# Altium schematic sheet WirelessEarBuds_PhotoHall.SchDoc
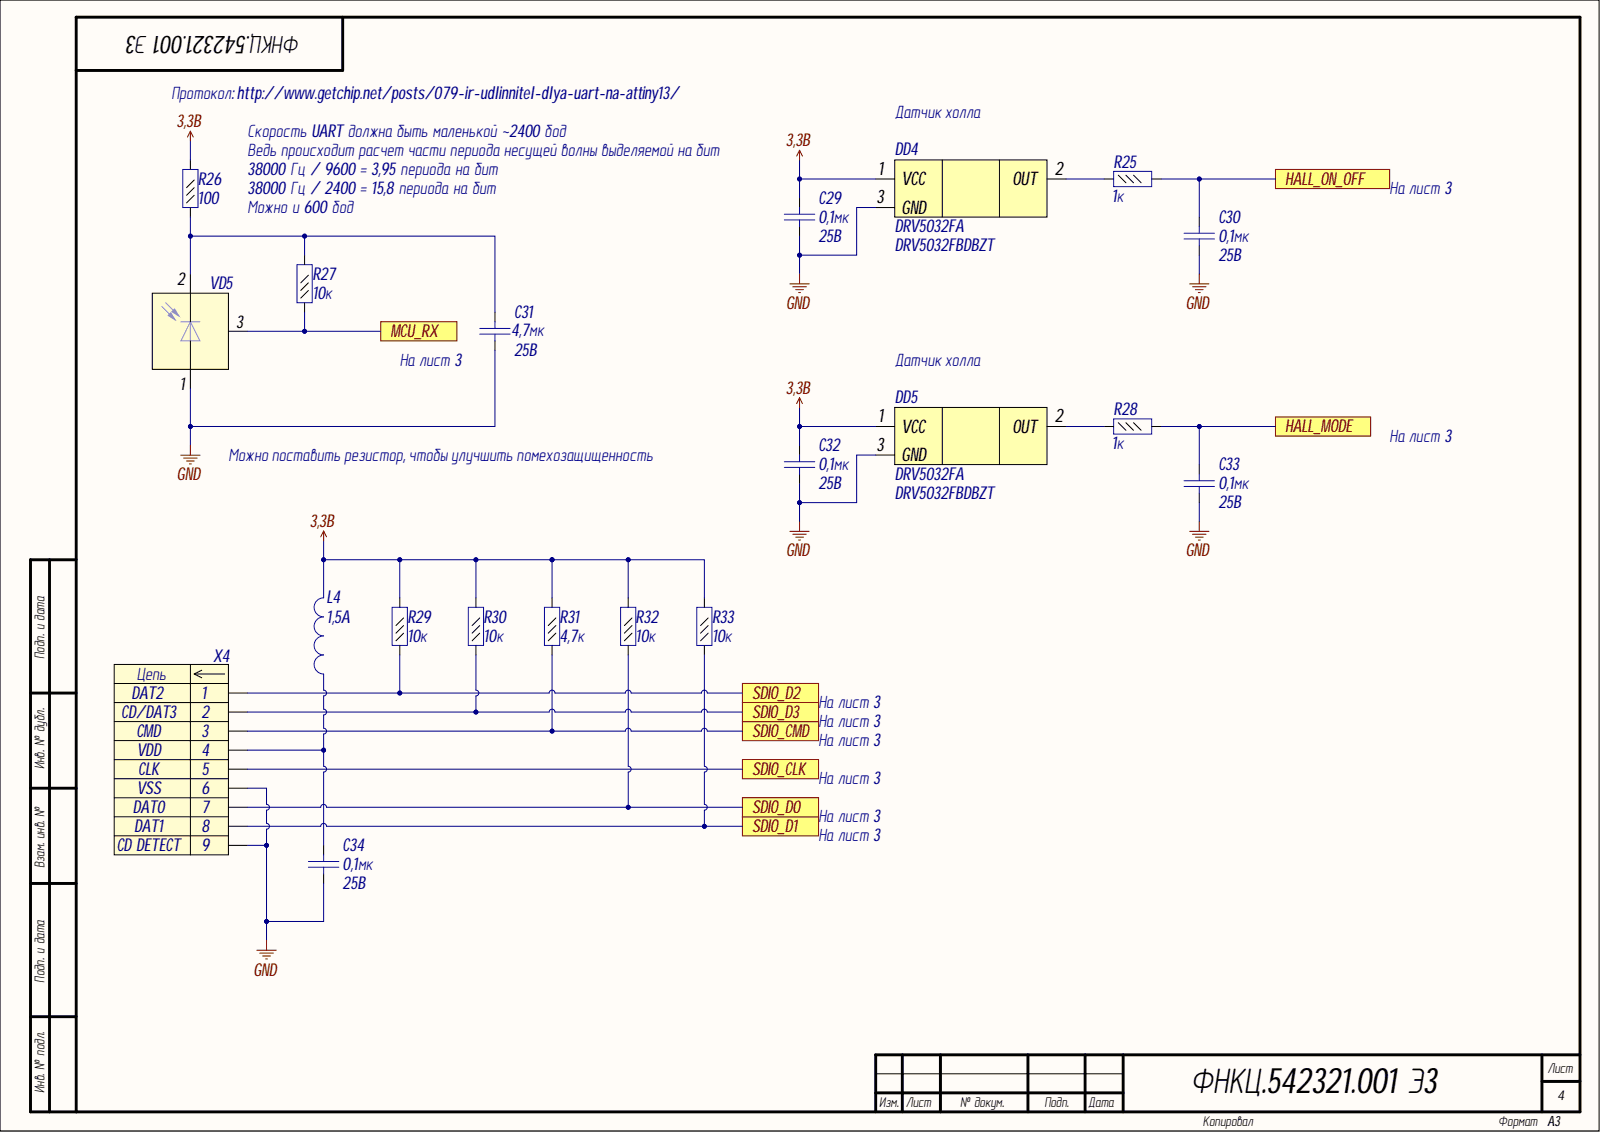
[diagram p0: WirelessEarBuds_PhotoHall.SchDoc]

## Parts
C29  value 0,1мк  Voltage: 25B  [C0402]  (hidden comment: Capacitor)
C30  value 0,1мк  Voltage: 25B  [C0402]  (hidden comment: Capacitor)
C31  value 4,7мк  Voltage: 25B  [C0805]  (hidden comment: Capacitor)
C32  value 0,1мк  Voltage: 25B  [C0402]  (hidden comment: Capacitor)
C33  value 0,1мк  Voltage: 25B  [C0402]  (hidden comment: Capacitor)
C34  value 0,1мк  Voltage: 25B  [C0402]  (hidden comment: Capacitor)
DD4  DRV5032  [SOT23-3]
DD5  DRV5032  [SOT23-3]
L4  Rated Current: 1,5A  [L0603]  (hidden comment: Inductor)
R25  value 1к  [R0402]  (hidden comment: Resistor_0,062Вт)
R26  value 100  [R0402]  (hidden comment: Resistor_0,062Вт)
R27  value 10к  [R0402]  (hidden comment: Resistor_0,062Вт)
R28  value 1к  [R0402]  (hidden comment: Resistor_0,062Вт)
R29  value 10к  [R0402]  (hidden comment: Resistor_0,062Вт)
R30  value 10к  [R0402]  (hidden comment: Resistor_0,062Вт)
R31  value 4,7к  [R0402]  (hidden comment: Resistor_0,062Вт)
R32  value 10к  [R0402]  (hidden comment: Resistor_0,062Вт)
R33  value 10к  [R0402]  (hidden comment: Resistor_0,062Вт)
VD5  IR_LED  [TVCAST]  (value not drawn)
X4  Contact_1: DAT2  Contact_2: CD/DAT3  Contact_3: CMD  Contact_4: VDD  Contact_5: CLK  Contact_6: VSS  Contact_7: DAT0  Contact_8: DAT1  [MICROSD]  (hidden comment: MICROSD-SDIO)

## Ports
HALL_MODE, HALL_ON_OFF, MCU_RX, SDIO_CLK, SDIO_CMD, SDIO_D0, SDIO_D1, SDIO_D2, SDIO_D3

## Nets
3,3B: C29.1 C32.1 DD4.1 DD5.1 L4.2 R26.2 R29.2 R30.2 R31.2 R32.2 R33.2
GND: C29.2 C30.2 C31.1 C32.2 C33.2 C34.2 DD4.3 DD5.3 VD5.1 X4.6 X4.9
HALL_MODE: C33.1 R28.2 ; port HALL_MODE
HALL_ON_OFF: C30.1 R25.2 ; port HALL_ON_OFF
MCU_RX: R27.1 VD5.3 ; port MCU_RX
SDIO_CLK: X4.5 ; port SDIO_CLK
SDIO_CMD: R31.1 X4.3 ; port SDIO_CMD
SDIO_D0: R32.1 X4.7 ; port SDIO_D0
SDIO_D1: R33.1 X4.8 ; port SDIO_D1
SDIO_D2: R29.1 X4.1 ; port SDIO_D2
SDIO_D3: R30.1 X4.2 ; port SDIO_D3
NetC31_2: C31.2 R26.1 R27.2 VD5.2
NetC34_1: C34.1 L4.1 X4.4
NetDD4_2: DD4.2 R25.1
NetDD5_2: DD5.2 R28.1

## Library data of the parts
C29 C30 C32-C34 = cap52: Конденсатор; Manufacture: Murata; Part Name: Ceramic; Part Number: GRM155F51E104Z**; Database Table Name: Capacitors$; TKE: Y5V; Component Kind: Standard; id: cap52; Library Name: MOP44.DbLib
C31 = cap154: Конденсатор; Manufacture: Murata; Part Name: Ceramic; Part Number: GRM21BR61E475KA12L; Database Table Name: Capacitors$; TKE: X5R; Component Kind: Standard; id: cap154; Library Name: MOP44.DbLib
DD4 DD5 = DRV5032FA: Датчик Холла с рекордно низким потреблением; Manufacture: Texas Instruments; Part Name: Hall sensor; Database Table Name: HallSensor$; id: hall1; MAGNETIC RESPONSE: Omnipolar; Magnetic threshold: 4,8mT; OUTPUT TYPE: Push-pull; Power Supply: 1,65B...5,5B; SAMPLING RATE: 20 Hz; Component Kind: Standard; Library Name: MOP44.DbLib; Part Number: DRV5032FA
L4 = ind0: EMI Suppression Filter; Part Name: EMI Suppression Filters; Part Number: BLM18PG181SN1D; Database Table Name: Inductor$; Resistance: 0,09Ohm; Component Kind: Standard; id: ind0; Library Name: MOP44.DbLib
R25 R28 = res266: Резистор; Manufacture: Yageo; Part Number: RC0402FR-071KL; Accuracy: 1%; Database Table Name: Resistor$; Component Kind: Standard; id: res266; Library Name: MOP44.DbLib; Part Name: Resistor; Power: 0,062Вт
R26 = res242: Резистор; Manufacture: Yageo; Part Number: RC0402FR-07100RL; Accuracy: 1%; Database Table Name: Resistor$; Component Kind: Standard; id: res242; Library Name: MOP44.DbLib; Part Name: Resistor; Power: 0,062Вт
R27 R29 R30 R32 R33 = res290: Резистор; Manufacture: Yageo; Part Number: RC0402FR-0710KL; Accuracy: 1%; Database Table Name: Resistor$; Component Kind: Standard; id: res290; Library Name: MOP44.DbLib; Part Name: Resistor; Power: 0,062Вт
R31 = res282: Резистор; Manufacture: Yageo; Part Number: RC0402FR-074K7; Accuracy: 1%; Database Table Name: Resistor$; Component Kind: Standard; id: res282; Library Name: MOP44.DbLib; Part Name: Resistor; Power: 0,062Вт
VD5 = diode26: Светодиод; Manufacture: Vishay; Part Name: IR Receiver Modules; Part Number: TSOP39238; Carrier Frequency: 38kHz; Database Table Name: Diode$; Detection Treshold: 0.08; Supply Voltage: 2,5-5,5B; Transmission Distance: 30m; Viewing Angle: (+-)45; Component Kind: Standard; id: diode26; Library Name: MOP44.DbLib
X4 = connect43: Database Table Name: Connector$; Component Kind: Standard; id: connect43; Library Name: MOP44.DbLib; Part Name: MICRO SD-SDIO
